# Altium schematic sheet Sheet1.SchDoc
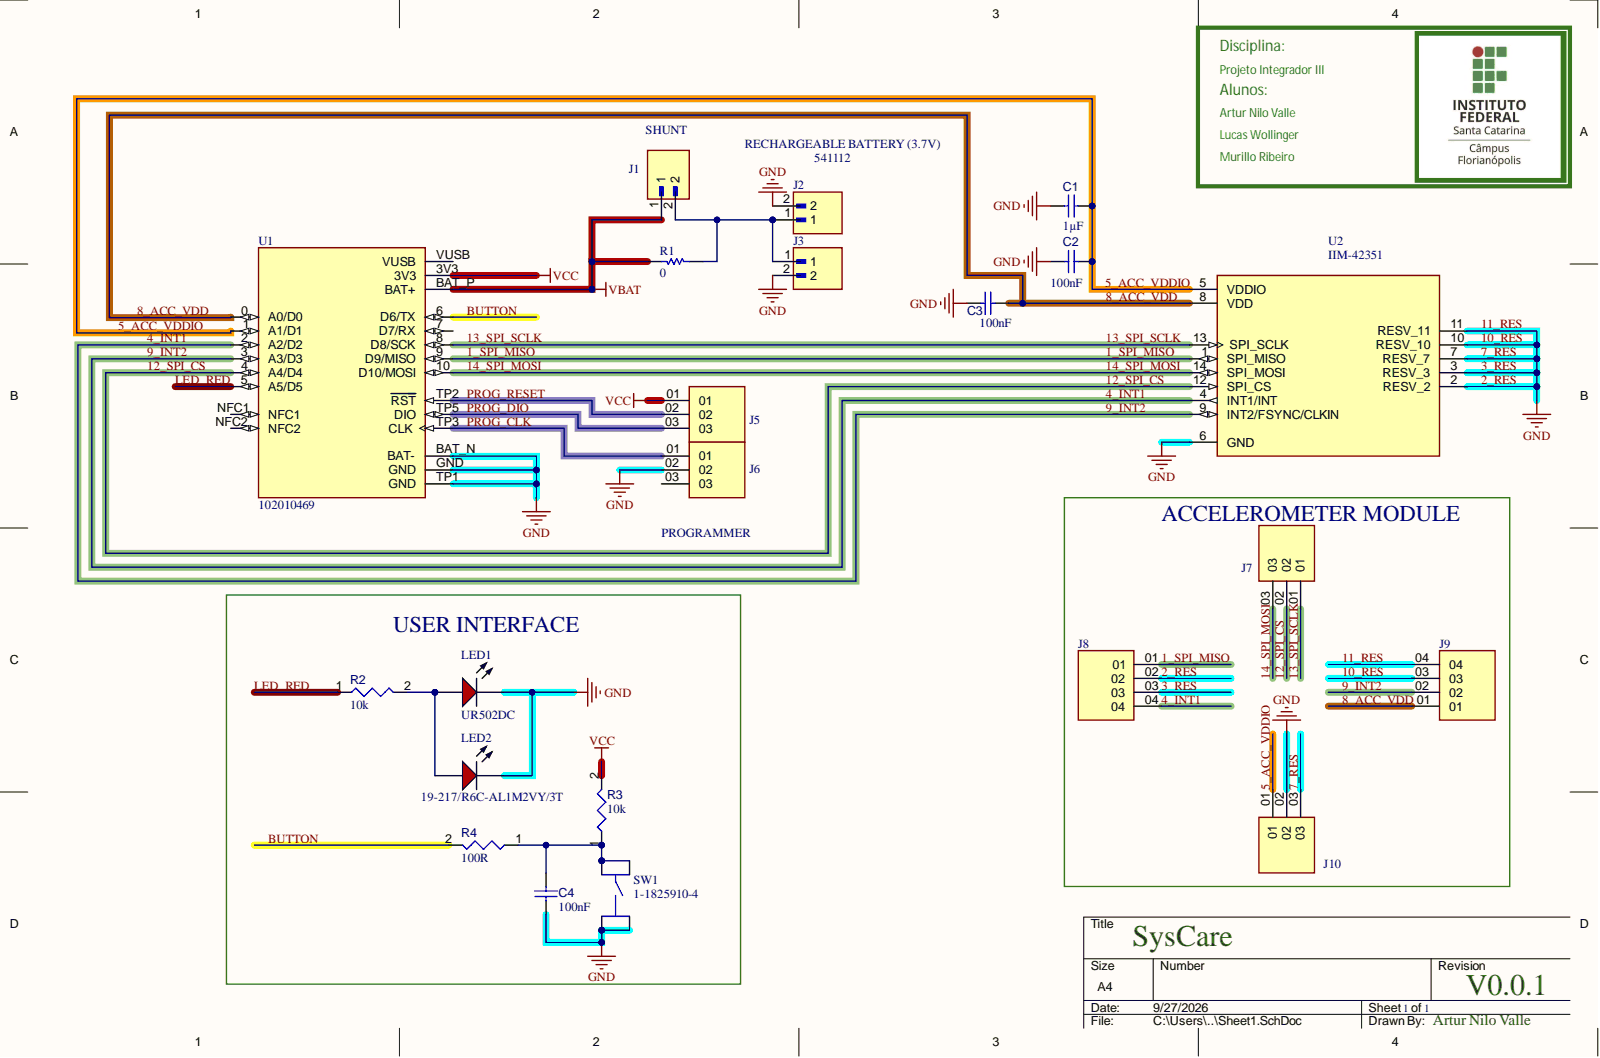
[diagram p0: Sheet1.SchDoc]

## Parts
C1  value 1µF  [CAPC1005X55X25LL05T10]  (hidden comment: Capacitor 1 uF +/- 10% 6.3 V 0402)
C2  value 100nF  [CAPC0402(1005)60_L]  (hidden comment: Capacitor 100nF +/-20% 10V 0402)
C3  value 100nF  [CAPC0402(1005)60_L]  (hidden comment: Capacitor 100nF +/-20% 10V 0402)
C4  value 100nF  [CAPC0402(1005)60_L]  (hidden comment: Capacitor 100nF +/-20% 10V 0402)
J1  DS1021-1X2SF162-B  [CONNFLY_DS1021-1X2SF162-B]  (value not drawn)
J2  DS1021-1X2SF162-B  [CONNFLY_DS1021-1X2SF162-B]  (value not drawn)
J3  DS1021-1X2SF162-B  [CONNFLY_DS1021-1X2SF162-B]  (value not drawn)
J5  TSW-103-07-T-S  [SAMTEC_TSW-103-07-T-S]  (value not drawn)
J6  TSW-103-07-T-S  [SAMTEC_TSW-103-07-T-S]  (value not drawn)
J7  TSW-103-07-T-S  [SAMTEC_TSW-103-07-T-S]  (value not drawn)
J8  TSW-104-07-G-S  [SAMTEC_TSW-104-07-G-S]  (value not drawn)
J9  TSW-104-07-G-S  [SAMTEC_TSW-104-07-G-S]  (value not drawn)
J10  TSW-103-07-T-S  [SAMTEC_TSW-103-07-T-S]  (value not drawn)
LED1  UR502DC  [CGX-UR502DC]
LED2  19-217/R6C-AL1M2VY/3T  [EVRL-0603-2_V]
R1  value 0  [RESC1005X40X25ML05T05]  (hidden comment: Resistor 0R +/- 5% 0402 100 mW)
R2  value 10k  [RESC0402(1005)_L]  (hidden comment: 10KR2F)
R3  value 10k  [RESC0402(1005)_L]  (hidden comment: 10KR2F)
R4  100R  [RESC0402(1005)_L]
SW1  1-1825910-4  [TE_1-1825910-4]
U1  102010469  [MODULE_102010469]
U2  IIM-42351  [IC_IIM-42351]

## Nets
VBAT: J1.1 R1.2 U1.BAT_P(BAT+)
VCC: J5.01 R3.2 U1.3V3
1_SPI_MISO: J8.01 U1.9(D9/MISO) U2.1(SPI_MISO)
2_RES: C1.2 C2.2 C3.1 C4.2 J2.2 J3.2 J6.02 J8.02 J8.03 J9.03 J9.04 J10.02 J10.03 LED1.2 LED2.2 SW1.3 SW1.4 U1.BAT_N(BAT-) U1.GND U1.TP1(GND) U2.2(RESV_2) U2.3(RESV_3) U2.6(GND) U2.7(RESV_7) U2.10(RESV_10) U2.11(RESV_11)
4_INT1: J8.04 J9.02 U1.2(A2/D2) U1.3(A3/D3) U2.4(INT1/INT) U2.9(INT2/FSYNC/CLKIN)
5_ACC_VDDIO: C1.1 C2.1 J10.01 U1.1(A1/D1) U2.5(VDDIO)
8_ACC_VDD: C3.2 J9.01 U1.0(A0/D0) U2.8(VDD)
12_SPI_CS: J7.02 U1.4(A4/D4) U2.12(SPI_CS)
13_SPI_SCLK: J7.01 U1.8(D8/SCK) U2.13(SPI_SCLK)
14_SPI_MOSI: J7.03 U1.10(D10/MOSI) U2.14(SPI_MOSI)
BUTTON: R4.2 U1.6(D6/TX)
LED_RED: R2.1 U1.5(A5/D5)
PROG_CLK: J6.01 U1.TP3(CLK)
PROG_DIO: J5.03 U1.TP5(DIO)
PROG_RESET: J5.02 U1.TP2(RST)
NetC4_1: C4.1 R3.1 R4.1 SW1.1 SW1.2
NetJ1_2: J1.2 J2.1 J3.1 R1.1
NetLED1_1: LED1.1 LED2.1 R2.2

Not connected: J6 03; U1 7(D7/RX) NFC1 NFC2 VUSB

## Library data of the parts
C1 = CMP-001-00008-6: Chip Capacitor, 1 uF, +/- 10%, 6.3 V, 0402 (1005 Metric); Capacitor Type: Ceramic; Voltage Rating: 6.3V; Case/Package: 0402; Component Kind: Standard; Max Operating Temperature: 85°C; Min Operating Temperature: -55°C; Mounting Technology: SM; Pins: 2; RoHS Compliant: True; Tolerance: 10%; Library Name: PI3 - IFSC
C2-C4 = CMP-001-00061-3: Chip Capacitor, 100nF +/-20%, 10V, 0402, Thickness 0.6 mm; Tolerance: 20%; Case/Package: 0402; Component Kind: Standard; Max Operating Temperature: 85°C; Min Operating Temperature: -55°C; Mounting Technology: SMT; Pins: 2; Voltage Rating: 10V; Library Name: PI3 - IFSC
J1-J3 = CMP-002-00071-1: Pin header; pin strips; male; PIN: 2; straight; 2.54mm; THT; 1x2; MF: Connfly; Case/Package: None; Check_prices: https://www.snapeda.com/parts/DS1021-1X2SF162-B/Connfly/view-part/?ref=eda; Component Kind: Standard; Component Type: Standard; Design Item ID: DS1021-1X2SF162-B; MP: DS1021-1X2SF162-B; Pins: 2; SnapEDA_Link: https://www.snapeda.com/parts/DS1021-1X2SF162-B/Connfly/view-part/?ref=snap; Source: DS1021-1X2SF162-B.SchLib; Library Name: PI3 - IFSC
J5-J7 J10 = CMP-023-000000-2: <a href="https://pricing.snapeda.com/parts/TSW-103-07-T-S/Samtec/view-part?ref=eda">Check availability</a>; MF: {'manufacturer': u'Samtec'}; Availability: Unavailable; Component Kind: Standard; Component Type: Standard; Design Item ID: TSW-103-07-T-S; MP: TSW-103-07-T-S; Package: None; Pin Count: 3; Price: None; Source: TSW-103-07-T-S.SchLib; Library Name: PI3 - IFSC
J8 J9 = CMP-021-000000-2: <a href="https://pricing.snapeda.com/parts/TSW-104-07-G-S/Samtec/view-part?ref=eda">Check availability</a>; MP: altium_native_batch; Availability: Unavailable; Component Kind: Standard; Component Type: Standard; Design Item ID: TSW-104-07-G-S; Package: None; Pin Count: 4; Price: None; Source: TSW-104-07-G-S.SchLib; Library Name: PI3 - IFSC
LED1 = CMP-007-00009-2: Red LED, 80 mW, 30 mA, -25 to 85 degC, 2-Pin THD; Manufacturer: Shenzhen CGX; Power: 80mW; Case/Package: UR502DC; Component Kind: Standard; Datasheet URL: http://datasheet.octopart.com/UR502DC-Chengguangxing-datasheet-62330342.pdf; Datasheet Version: Sep-14; Manufacturer URL: http://szcgx.com/; Mounting Technology: TH; Package Description: 2-Pin Through Hole Device, Dia 5.9 mm, Height 9.1 mm; Package Reference: UR502DC; RoHS Compliant: Unknown; Library Name: PI3 - IFSC
LED2 = CMP-007-00004-2: Chip LED, Red, 5 V, 25 mA, -40 to 85 degC, 2-Pin SMD (0603), RoHS, Tape and Reel; Manufacturer: Everlight Electronics; Forward Voltage: 2.2V; Lens Style: Clear; Case/Package: 0603; Colour: Red; Component Kind: Standard; Datasheet URL: https://datasheet.ciiva.com/4688/el-19-217-r6c-al1m2vy-3tpdf-187026-4688713.pdf; Datasheet Version: Rev. 1, 01/2005; Manufacturer URL: http://www.everlight.com/; Mounting Technology: SM; Package Description: 2-Pin Surface Mount Device, Body 1.6 x 0.8 mm; Package Reference: 0603; RoHS Compliant: False; Library Name: PI3 - IFSC
R1 = CMP-009-00005-5: Chip Resistor, 0 Ohm, 0.1 W, -55 to 155 degC, 0402 (1005 Metric); Power: 100mW; Voltage Rating: 50V; Case/Package: 0402; Component Kind: Standard; Max Operating Temperature: 155°C; Min Operating Temperature: -55°C; Mounting Technology: SM; Pins: 2; RoHS Compliant: True; Tolerance: 5%; Library Name: PI3 - IFSC
R2 R3 = CMP-009-00066-2: 10K 0.063W 1% 0402 (1005 Metric)  SMD; Power: 63mW; Case/Package: 0402; Component Kind: Standard; Mounting Technology: SMT; Pins: 2; Tolerance: 1%; Library Name: PI3 - IFSC
R4 = CMP-009-00066-2: 100 0.063W 1% 0402 (1005 Metric)  SMD; Power: 63mW; Case/Package: 0402; Component Kind: Standard; Mounting Technology: SMT; Pins: 2; Tolerance: 1%; Value: 100R; Library Name: PI3 - IFSC
SW1 = CMP-011-00014-2: FSM8JH=6MM TACT SWITCH, HIGH TEMP; MF: TE Connectivity ALCOSWITCH Switches; Case/Package: None; Check_prices: https://www.snapeda.com/parts/1-1825910-4/TE+Connectivity+Alcoswitch+Switches/view-part/?ref=eda; Component Kind: Standard; Component Type: Standard; Design Item ID: 1-1825910-4; MP: 1-1825910-4; Pins: 4; SnapEDA_Link: https://www.snapeda.com/parts/1-1825910-4/TE+Connectivity+Alcoswitch+Switches/view-part/?ref=snap; Source: 1-1825910-4.SchLib; Library Name: PI3 - IFSC
U1 = CMP-003-000000-1: nRF52840 - Transceiver; Bluetooth® 5.x (BLE) 2.4GHz Evaluation Board; MF: Seeed Technology Co., Ltd; Check_prices: https://www.snapeda.com/parts/102010469/Seeed+Studio/view-part/?ref=eda; Component Kind: Standard; Component Type: Standard; Design Item ID: 102010469; MP: 102010469; Package: None; Pin Count: 22; SnapEDA_Link: https://www.snapeda.com/parts/102010469/Seeed+Studio/view-part/?ref=snap; Source: 102010469.SchLib; Library Name: PI3 - IFSC
U2 = CMP-005-000000-3: Accelerometer X, Y, Z Axis ±2g, 4g, 8g, 16g 6.25Hz ~ 4kHz 14-LGA (2.5x3); MF: {'manufacturer': u'TDK InvenSense'}; Availability: Unavailable; Component Kind: Standard; Component Type: Standard; Design Item ID: IIM-42351; MP: IIM-42351; Package: None; Pin Count: 14; Price: None; Source: IIM-42351.SchLib; Library Name: PI3 - IFSC
